# Altium schematic sheet Rangefinder.SchDoc
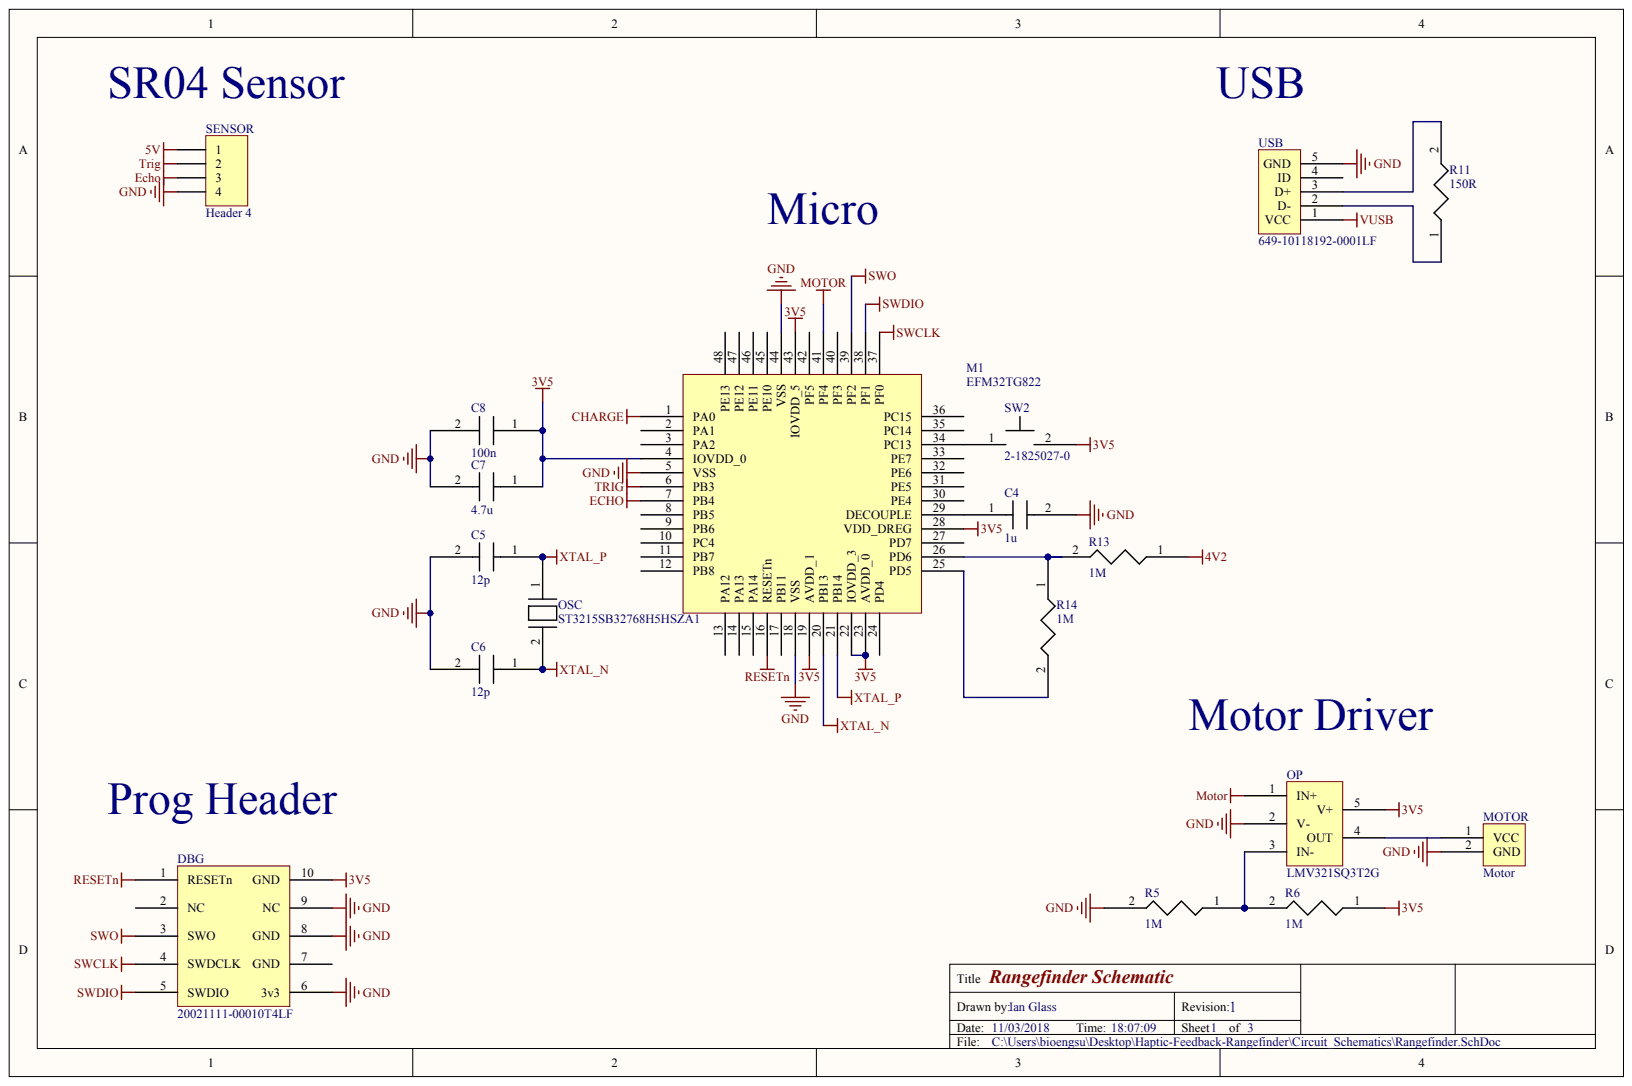
[diagram p0: Rangefinder.SchDoc]

## Parts
C4  1u
C5  12p
C6  12p
C7  4.7u
C8  100n
DBG  20021111-00010T4LF
M1  EFM32TG822
MOTOR  Motor
OP  LMV321SQ3T2G
OSC  ST3215SB32768H5HSZA1
R5  1M
R6  1M
R11  150R
R13  1M
R14  1M
SENSOR  Header 4
SW2  2-1825027-0
USB  649-10118192-0001LF

## Nets
3V5: C7.1 C8.1 DBG.10(GND) M1.4(IOVDD_0) M1.19(AVDD_1) M1.22(IOVDD_3) M1.23(AVDD_0) M1.28(VDD_DREG) M1.43(IOVDD_5) OP.5(V+) R6.1 SW2.2
4V2: R13.1
5V: SENSOR.1
CHARGE: M1.1(PA0)
ECHO: M1.7(PB4) SENSOR.3
GND: C4.2 C5.2 C6.2 C7.2 C8.2 DBG.6(3v3) DBG.8(GND) DBG.9(NC) M1.5(VSS) M1.18(VSS) M1.44(VSS) MOTOR.2 OP.2(V-) R5.2 SENSOR.4 USB.5(GND)
MOTOR: M1.41(PF4) OP.1(IN+)
RESETN: DBG.1(RESETn) M1.16(RESETn)
SWCLK: DBG.4(SWDCLK) M1.37(PF0)
SWDIO: DBG.5(SWDIO) M1.38(PF1)
SWO: DBG.3(SWO) M1.39(PF2)
TRIG: M1.6(PB3) SENSOR.2
VUSB: USB.1(VCC)
XTAL_N: C6.1 M1.20(PB13) OSC.2
XTAL_P: C5.1 M1.21(PB14) OSC.1
NetC4_1: C4.1 M1.29(DECOUPLE)
NetM1_25: M1.25(PD5) R14.2
NetM1_26: M1.26(PD6) R13.2 R14.1
NetM1_34: M1.34(PC13) SW2.1
NetMOTOR_1: MOTOR.1 OP.4(OUT)
NetOP_3: OP.3(IN-) R5.1 R6.2
NetR11_1: R11.1 USB.2(D-)
NetR11_2: R11.2 USB.3(D+)

Not connected: DBG 2(NC) 7(GND); M1 2(PA1) 3(PA2) 8(PB5) 9(PB6) 10(PC4) 11(PB7) 12(PB8) 13(PA12) 14(PA13) 15(PA14) 17(PB11) 24(PD4) 27(PD7) 30(PE4) 31(PE5) 32(PE6) 33(PE7) 35(PC14) 36(PC15) 40(PF3) 42(PF5) 45(PE10) 46(PE11) 47(PE12) 48(PE13); USB 4(ID)

## Library data of the parts
C4-C8 DBG M1 MOTOR OP OSC R5 R6 R11 R13 R14 SENSOR SW2 USB = (no symbol name)
  SENSOR: LatestRevisionDate: 17-Jul-2002; LatestRevisionNote: Re-released for DXP Platform.; Publisher: Altium Limited
